# Altium schematic sheet RA_Local_Promotion_Board_3.SchDoc(RA_Local_Promotion_Board_3)
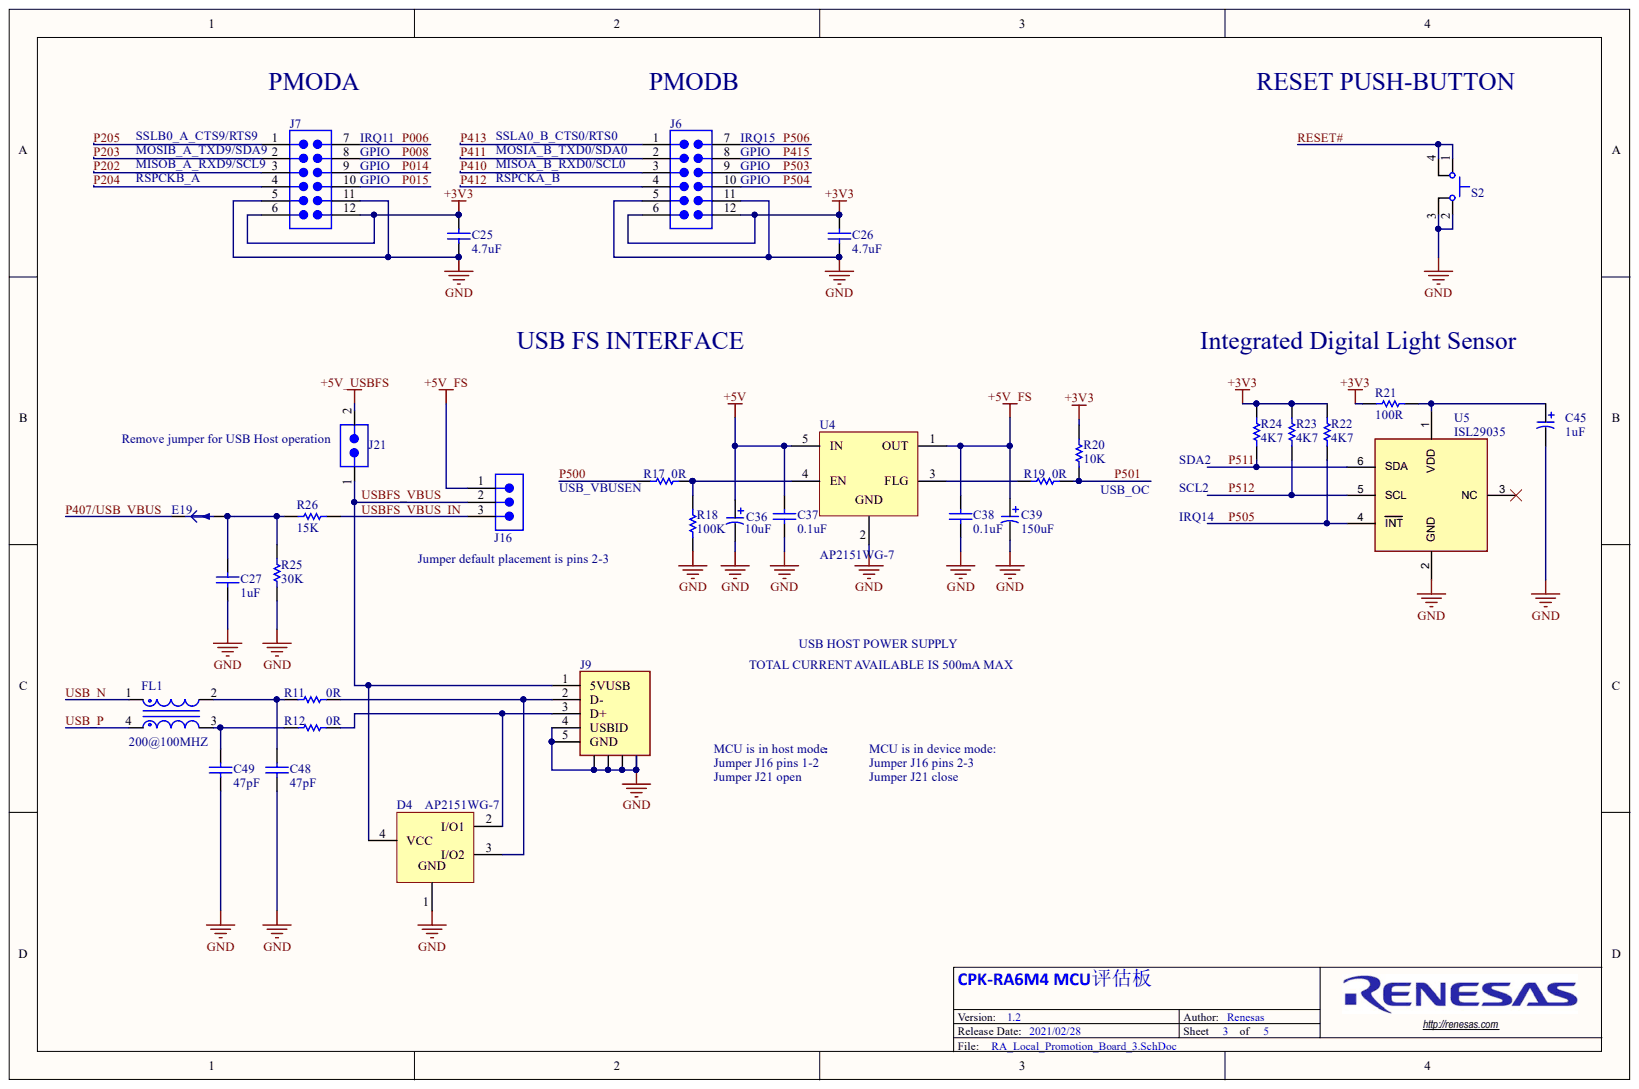
[diagram p0: RA_Local_Promotion_Board_3.SchDoc]

## Parts
C25  4.7uF  [CAPC0603-01]
C26  4.7uF  [CAPC0603-01]
C27  1uF  [CAPC0603-01]
C36  10uF  [ELEC A]
C37  0.1uF  [CAPC0603-01]
C38  0.1uF  [CAPC0603-01]
C39  150uF  [CAPSC2917(7343)]
C45  1uF  [C1206 (3216)]
C48  Capacitance: 47pF  [CAPC0603-01]  (hidden comment: 470pF)
C49  Capacitance: 47pF  [CAPC0603-01]  (hidden comment: 470pF)
D4  AP2151WG-7  [SOT-143B]
E19  Jumper Trace Cut  [E_PadsTraceCut0805]  (value not drawn)  (no BOM)
FL1  value 200@100MHZ  [CKS4B20X12X]  (hidden comment: 90R)
J6  PMOD  [SULLINS_PPTC062LFBN-RC-PMOD]  (value not drawn)
J7  PMOD  [SULLINS_PPTC062LFBN-RC-PMOD]  (value not drawn)
J9  UBAF-0608  [FCI_10104111-0001LF]  (value not drawn)
J16  2.54mm 1x3  [FCI_6800-x03]  (value not drawn)
J21  2.54mm 1x2  [FCI_67966-X02]  (value not drawn)
R11  0R  [RESC0603-01]
R12  0R  [RESC0603-01]
R17  0R  [RESC0603-01]
R18  100K  [RESC0603-01]
R19  0R  [RESC0603-01]
R20  10K  [RESC0603-01]
R21  100R  [RESC0603-01]
R22  4K7  [RESC0603-01]
R23  4K7  [RESC0603-01]
R24  4K7  [RESC0603-01]
R25  30K  [RESC0603-01]
R26  15K  [RESC0603-01]
S2  Switch 4.5x4.5mm  [KEY A (4.5 X 4.5)]  (value not drawn)
U4  AP2151WG-7  [SOT25]
U5  ISL29035  [6-ODFN-29035]

## Nets
+3V3: C25.2 C26.2 J6.6 J6.12 J7.6 J7.12 R20.2 R21.1 R22.2 R23.2 R24.2
+5V: C36.1 C37.2 U4.5(IN)
+5V_FS: C38.2 C39.1 J16.1 U4.1(OUT)
+5V_USBFS: J21.2
GND: C25.1 C26.1 C27.1 C36.2 C37.1 C38.1 C39.2 C45.2 C48.1 C49.1 D4.1 J6.5 J6.11 J7.5 J7.11 J9.4(USBID) J9.5(GND) J9.C1 J9.C2 J9.C3 J9.C4 R18.1 R25.1 S2.2 S2.3 U4.2 U5.2(GND)
P006: J7.7
P008: J7.8
P014: J7.9
P015: J7.10
P202: J7.3
P203: J7.2
P204: J7.4
P205: J7.1
P407/USB_VBUS: E19.1
P410: J6.3
P411: J6.2
P412: J6.4
P413: J6.1
P415: J6.8
P500: R17.1
P501: R19.2 R20.1
P503: J6.9
P504: J6.10
P505: R22.1 U5.4(INT)
P506: J6.7
P511: R24.1 U5.6(SDA)
P512: R23.1 U5.5(SCL)
RESET#: S2.1 S2.4
USB_N: FL1.1
USB_P: FL1.4
USBFS_VBUS: D4.4(VCC) J9.1(5VUSB) J16.2 J21.1
USBFS_VBUS_IN: J16.3 R26.2
NetC27_2: C27.2 E19.2 R25.2 R26.1
NetC45_1: C45.1 R21.2 U5.1(VDD)
NetC48_2: C48.2 FL1.2 R11.1
NetC49_2: C49.2 FL1.3 R12.1
NetD4_2: D4.2(I/O1) J9.3(D+) R12.2
NetD4_3: D4.3(I/O2) J9.2(D-) R11.2
NetR17_2: R17.2 R18.2 U4.4(EN)
NetR19_1: R19.1 U4.3(FLG)

Not connected: U5 3(NC)

## Library data of the parts
C25 C26 = CAP-0603-4.7uF: Ceramic Chip Capacitor 0603 4.7uF 6.3V; Manufacturer: TDK; Mfg Part Number: CGA3E1X5R0J475K080AC; Dialectric: X5R; Tolerance: 10%; Capacitance: 4.7uF; Component Kind: Standard; Package: 0603; Voltage: 6.3V; Library Name: Synergy.SchLib
C27 = CAP-0603-1uF: Ceramic Chip Capacitor 0603 1uF 50V; Manufacturer: MURATA; Mfg Part Number: GRT188R61H105KE13D; Dialectric: X5R; Tolerance: 10%; Capacitance: 1uF; Component Kind: Standard; Library Name: New Com.SchLib; Package: 0603; Voltage: 50V
C36 = CAP-1206-10uF: Tantalum Capacitor 1206 10uF 16V; Manufacturer: AVX; Mfg Part Number: TAJA106K016SNJ; Tolerance: 10%; Capacitance: 10uF; Component Kind: Standard; Package: 1206; Voltage: 16V; Library Name: Synergy.SchLib
C37 C38 = CAP-0603-0.1uF: Ceramic Chip Capacitor 0603 0.1uF 50V; Manufacturer: MURATA; Mfg Part Number: GCM188L81H104KA57D; Dialectric: X8L; Tolerance: 10%; Capacitance: 0.1uF; Component Kind: Standard; Library Name: New Com.SchLib; Package: 0603; Voltage: 50V
C39 = CAP-2917-150uF: Tantalum Capacitor 2917 150uF 16V; Manufacturer: AVX; Mfg Part Number: TPSE157K016R0050V; Tolerance: 10%; Capacitance: 150uF; Component Kind: Standard; Library Name: New Com.SchLib; Package: 2917; Voltage: 16V
C45 = CAP-1206-1uF: Tantalum Capacitor 1uF 25V 20% 1206; Manufacturer: AVX; Mfg Part Number: TAJA105M025RNJ; Capacitance: 1uF; Component Kind: Standard; Library Name: New Com.SchLib; Package: 1206; Tolerance: 20%; Voltage: 25V
C48 C49 = CAP-0603-470pF: Ceramic Chip Capacitor 0603 470pF 50V; Manufacturer: Murata; Mfg Part Number: GRM1885C1H471JA01J; Dialectric: C0G/NP0; Tolerance: 5%; Component Kind: Standard; Library Name: New Com.SchLib; Package: 0603; Voltage: 50V
D4 = DIO-PRTR5V0U2X: IC PWR SWITCH P-CHAN 1:1 SOT25; Manufacturer: Diodes; Component Kind: Standard; Library Name: RA_Design.SCHLIB; Mfg Part Number: AP2151WG-7; Package: SOT25; Part Number: AP2151WG-7
E19 = E_JmpTraceCut: Pads on PCB with Trace Between for Cutting to isolate; Part Type: Jumper Trace Cut; Library Name: Synergy.SchLib
FL1 = IND-SMD0805-LC: Common Choke,90ohm@100MHz/20°C,±25%,330mA,0.35ohm,SMD0805; Manufacturer: Murata; Mfg Part Number: DLW21SN900SQ2B; Value: 200@100MHZ; Component Kind: Standard; Part Type: Common Mode Inductor; Rating: 330mA; Library Name: Synergy.SchLib
J6 J7 = CON-2.54mm 2x6 Female: Female Header 0.1" pitch 2x6 Female; Manufacturer: Sullins; Mfg Part Number: PPTC062LFBN-RC; Pitch: 2.54mm; Positions: 12; Component Kind: Standard; Library Name: Synergy.SchLib
J9 = USB-UBAF-0608: Connector,MicroUSB B port,5 Position,Right Angle,Gold Flash 1u,black,SMD; Manufacturer: MTCONN; PCB Footprint: USBSB5_6; Component Kind: Standard; Mfg Part Number: UBAF-0608; Part Type: USB Connector; Value: UBAF-0608; Library Name: Synergy.SchLib
J16 = CON-2.54mm 1x3: Header 0.1" pitch 3pos 1x3; Manufacturer: FCI; Mfg Part Number: 77311-818-03LF; Positions: 3; Component Kind: Standard; Pitch: 0.1"; Library Name: Synergy.SchLib
J21 = CON-2.54mm 1x2: FCI Header 0.1" pitch 2pos 1x2; Mfg Part Number: 67997-402HLF; Positions: 2; Component Kind: Standard; Manufacturer: FCI; Pitch: 2.54mm; Library Name: Synergy.SchLib
R11 R12 R17 R19 = RES-0603-0R: Chip Resistor Thick Film 0603 0R 1% 1/10W; Manufacturer: YAGEO; Mfg Part Number: RC0603FR-070RL; Component Kind: Standard; Library Name: New Com.SchLib; Package: 0603; Power: 1/10W; Resistance: 0R; Tolerance: 1%
R18 = RES-0603-100K: Chip Resistor Thick Film 0603 100K 1% 1/10W; Manufacturer: YAGEO; Mfg Part Number: RC0603FR-07100KL; Component Kind: Standard; Library Name: New Com.SchLib; Package: 0603; Power: 1/10W; Resistance: 100K; Tolerance: 1%
R20 = RES-0603-10K: Chip Resistor Thick Film 0603 10K 1% 1/10W; Manufacturer: YAGEO; Mfg Part Number: RC0603FR-0710KL; Component Kind: Standard; Library Name: New Com.SchLib; Package: 0603; Power: 1/10W; Resistance: 10K; Tolerance: 1%
R21 = RES-0603-200R: Chip Resistor Thick Film 0603 100R 1% 1/10W; Manufacturer: YAGEO; Mfg Part Number: RC0603FR-07100RL; Component Kind: Standard; Library Name: New Com.SchLib; Package: 0603; Power: 1/10W; Resistance: 100R; Tolerance: 1%
R22-R24 = RES-0603-4K7: Chip Resistor Thick Film 0603 4K7 1% 1/10W; Manufacturer: YAGEO; Mfg Part Number: RC0603FR-074K7L; Component Kind: Standard; Library Name: New Com.SchLib; Package: 0603; Power: 1/10W; Resistance: 4K7; Tolerance: 1%
R25 = RES-0603-30K: Chip Resistor Thick Film 0603 30K 1% 1/10W; Manufacturer: YAGEO; Mfg Part Number: RC0603FR-0730KL; Component Kind: Standard; Library Name: New Com.SchLib; Package: 0603; Power: 1/10W; Resistance: 30K; Tolerance: 1%
R26 = RES-0603-15K: Chip Resistor Thick Film 0603 15K 1% 1/10W; Manufacturer: YAGEO; Mfg Part Number: RC0603FR-0715KL; Component Kind: Standard; Library Name: New Com.SchLib; Package: 0603; Power: 1/10W; Resistance: 15K; Tolerance: 1%
S2 = SWT-4.5x4.5mm: Push Button Switch 4.5 x 4.5mm 3.8mm High; Manufacturer: C&K; Mfg Part Number: PTS647SM38SMTR2 LFS; Component Kind: Standard; Library Name: New Com.SchLib
U4 = ICN-AP2151WG-7: IC PWR SWITCH P-CHAN 1:1 SOT25; Manufacturer: Diodes; Component Kind: Standard; Library Name: New Com.SchLib; Mfg Part Number: AP2151WG-7; Package: SOT25; Part Number: AP2151WG-7
U5 = ICN-ISL29035: Integrated Digital Light Sensor  6 LD ODFN; Manufacturer: Renesas; Component Kind: Standard; Library Name: New Com.SchLib; Mfg Part Number: ISL29035; Package: 6 LD ODFN; Part Name: ISL29035
